# Altium schematic sheet 05_COTS_Avionics.SchDoc(05_COTS_Avionics)
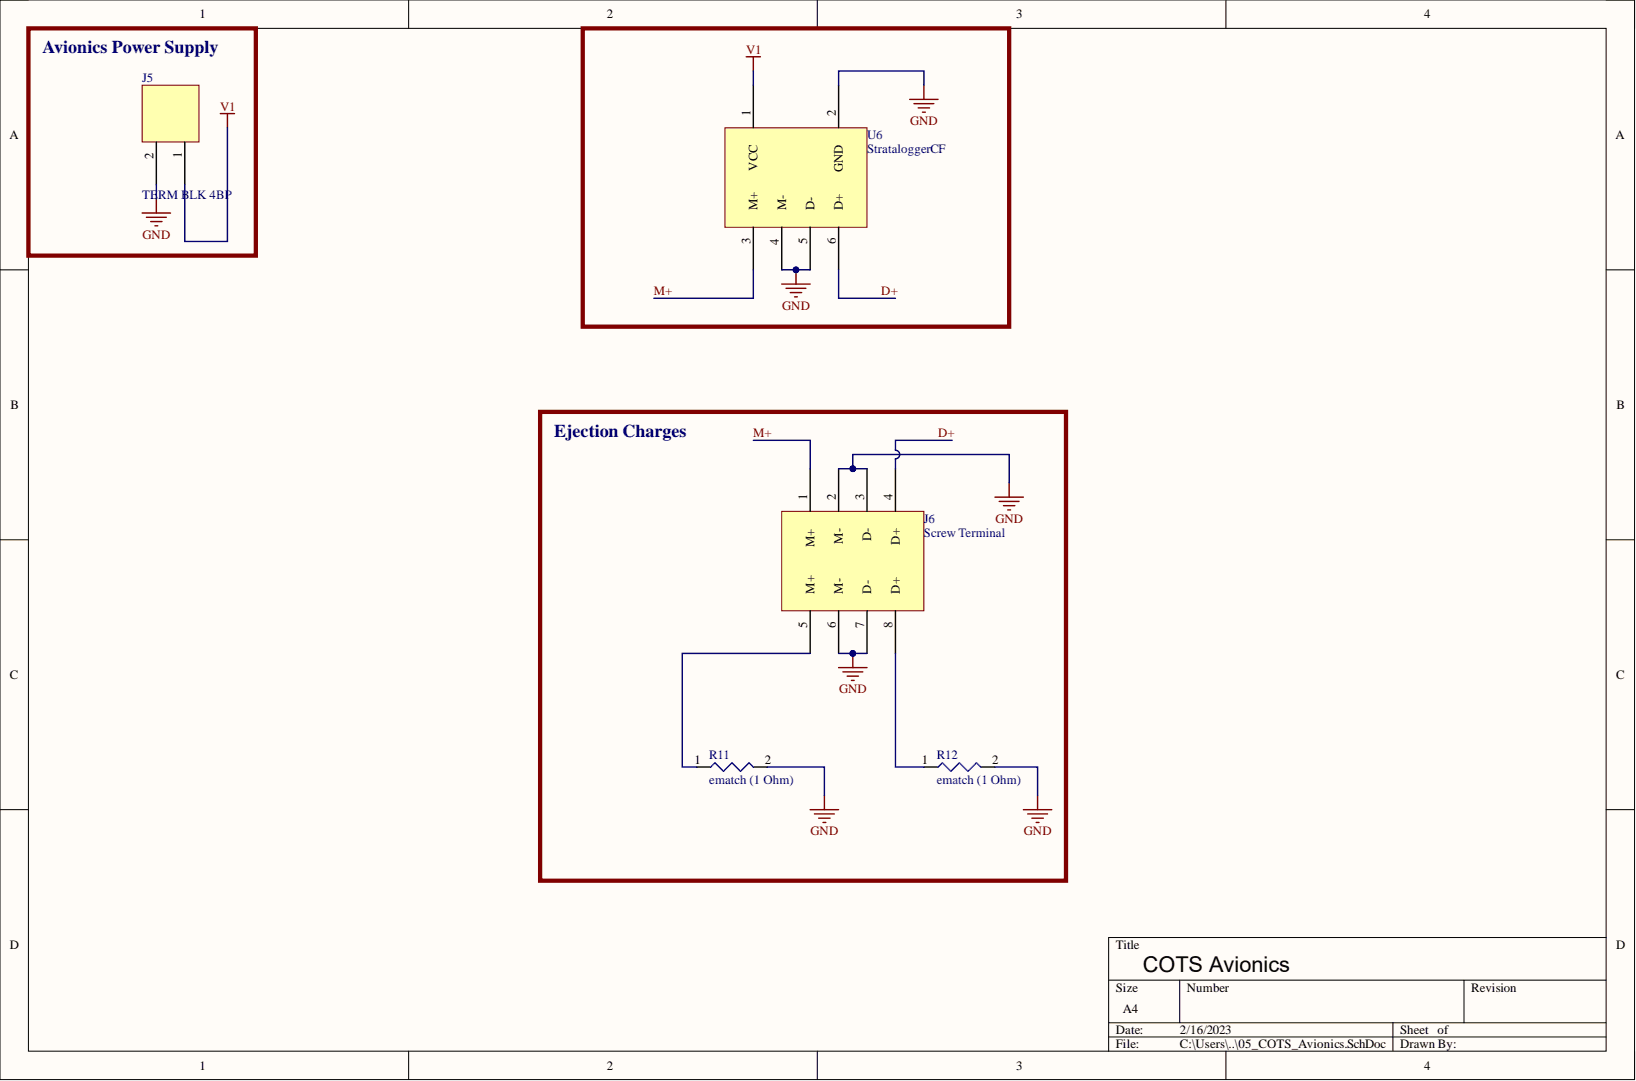
[diagram p0: 05_COTS_Avionics.SchDoc]

## Parts
J5  TERM BLK 4BP  [PCB TERM BLK 2P 2.54MM]
J6  Screw Terminal  [Barrier Screw Terminal]
R11  ematch (1 Ohm)  [ematch]
R12  ematch (1 Ohm)  [ematch]
U6  StrataloggerCF  [StrataloggerCF]

## Nets
GND: J5.2 J6.2 J6.3 J6.6 J6.7 R11.2 R12.2 U6.2 U6.4 U6.5
V1: J5.1 U6.1
D+: J6.4 U6.6
M+: J6.1 U6.3
NetJ6_5: J6.5 R11.1
NetJ6_8: J6.8 R12.1

## Library data of the parts
J5 = PCB TERM BLK 2P 2.54MM: 2 Position Wire to Board Terminal Block Horizontal with Board 0.100" (2.54mm) Through Hole 10A 150V 16-30 AWG 0.2 Nm M1.6 screw -40°C ~ 105°C; Manufacturer: TE Connectivity; Manufacturer Part Number: 282834-2; Approvals: UL; Closed End: No (Without); Connector Type: Receptacle; Gender: Male; Number of Circuits: 2; Number of Levels: 1; Plating: Brass,Steel; Screwless Terminal Block: Yes (With); Tail Length: 3.4mm; Wire Gauge (Max): 30AWG; Category: Terminal Blocks; Color: Green; Component Kind: Standard; ComponentLink1Description: https://datasheet.ciiva.com/16386/282834-2-16386363.pdf?src-supplier=Future+Electronics; ComponentLink1URL: https://datasheet.ciiva.com/16386/282834-2-16386363.pdf?src-supplier=Future+Electronics; ComponentLink2Description: https://datasheet.ciiva.com/30166/eng-cs-1308389-eurostyle-terminal-blocks-0607-30166974.pdf?src-supplier=Onlinecomponents.com; ComponentLink2URL: https://datasheet.ciiva.com/30166/eng-cs-1308389-eurostyle-terminal-blocks-0607-30166974.pdf?src-supplier=Onlinecomponents.com; ComponentLink3Description: https://datasheet.ciiva.com/14609/70086732-14609670.pdf?src-supplier=Allied+Electronics; ComponentLink3URL: https://datasheet.ciiva.com/14609/70086732-14609670.pdf?src-supplier=Allied+Electronics; ComponentLink4Description: https://datasheet.ciiva.com/15169/getdatasheetpartid-155864-15169558.pdf?src-supplier=Verical; ComponentLink4URL: https://datasheet.ciiva.com/15169/getdatasheetpartid-155864-15169558.pdf?src-supplier=Verical; ComponentLink5Description: https://datasheet.ciiva.com/3141/eng-cs-1308389-eurostyle-terminal-blocks-0607-3141115.pdf?src-supplier=Avnet; ComponentLink5URL: https://datasheet.ciiva.com/3141/eng-cs-1308389-eurostyle-terminal-blocks-0607-3141115.pdf?src-supplier=Avnet; Contact Material: Brass; Contact Plating: Tin; Current: 10A; Current Rating: 10A; Depth: 6.5mm; ELV: Compliant; Flammability Rating: UL94 V-0; Housing Color: Green; Housing Material: Polyamide; Interlock: No (Without); Lead Free: Lead Free; Length: 5.54mm; Material: Nylon; Max Current Rating: 10A; Max Operating Temperature: 105°C; Max Supply Voltage (AC): 150V; Max Voltage Rating (AC): 150V; Min Operating Temperature: -40°C; Mounting Technology: Through Hole; Number of Contacts: 2; Number of Poles: 2; Number of Positions: 2; Number of Positions Per Level: 2; Number of Rows: 1; Orientation: Straight; Package Quantity: 300; Packaging: Bulk; Pitch: 2.54mm; Pricing 1: 1=1.95000, 10=1.47400, 25=1.36640, 50=1.22860, 100=1.19860, 250=1.04876, 500=0.95886, 1000=0.86896, 5000=0.76409 (USD); Radiation Hardening: No; RoHS: Yes; RoHS Compliant: Yes; Screw Flange: No (Without); Series: Buchanan; Side Stackable: Yes (With); Stock 1: 34508; Supplier 1: Digi-Key; Supplier Part Number 1: A98333-ND; Termination: Solder; Thread Size: M1.6; Voltage: 150V; Voltage Rating: 150V; Width: 6.5mm; Wire Gauge (Min): 30AWG; Wire/Cable Gauge: 30AWG; Library Name: SRAD_SAC_Schlib.SchLib
J6 = Barrier Screw Terminal: Component Kind: Standard; Library Name: SRAD_SAC_Schlib.SchLib
R11 R12 = ematch: ematch of 1.0-1.5 Ohms of resistance that lights black powder ejection charges.; Component Kind: Standard; Library Name: SRAD_SAC_Schlib.SchLib
U6 = StrataloggerCF: PerfectFlite StrataloggerCF COTS altimeter.; Component Kind: Standard; Library Name: SRAD_SAC_Schlib.SchLib
